# Altium schematic sheet PAGE2-CONNECTIONS.SchDoc(PAGE2-CONNECTIONS)
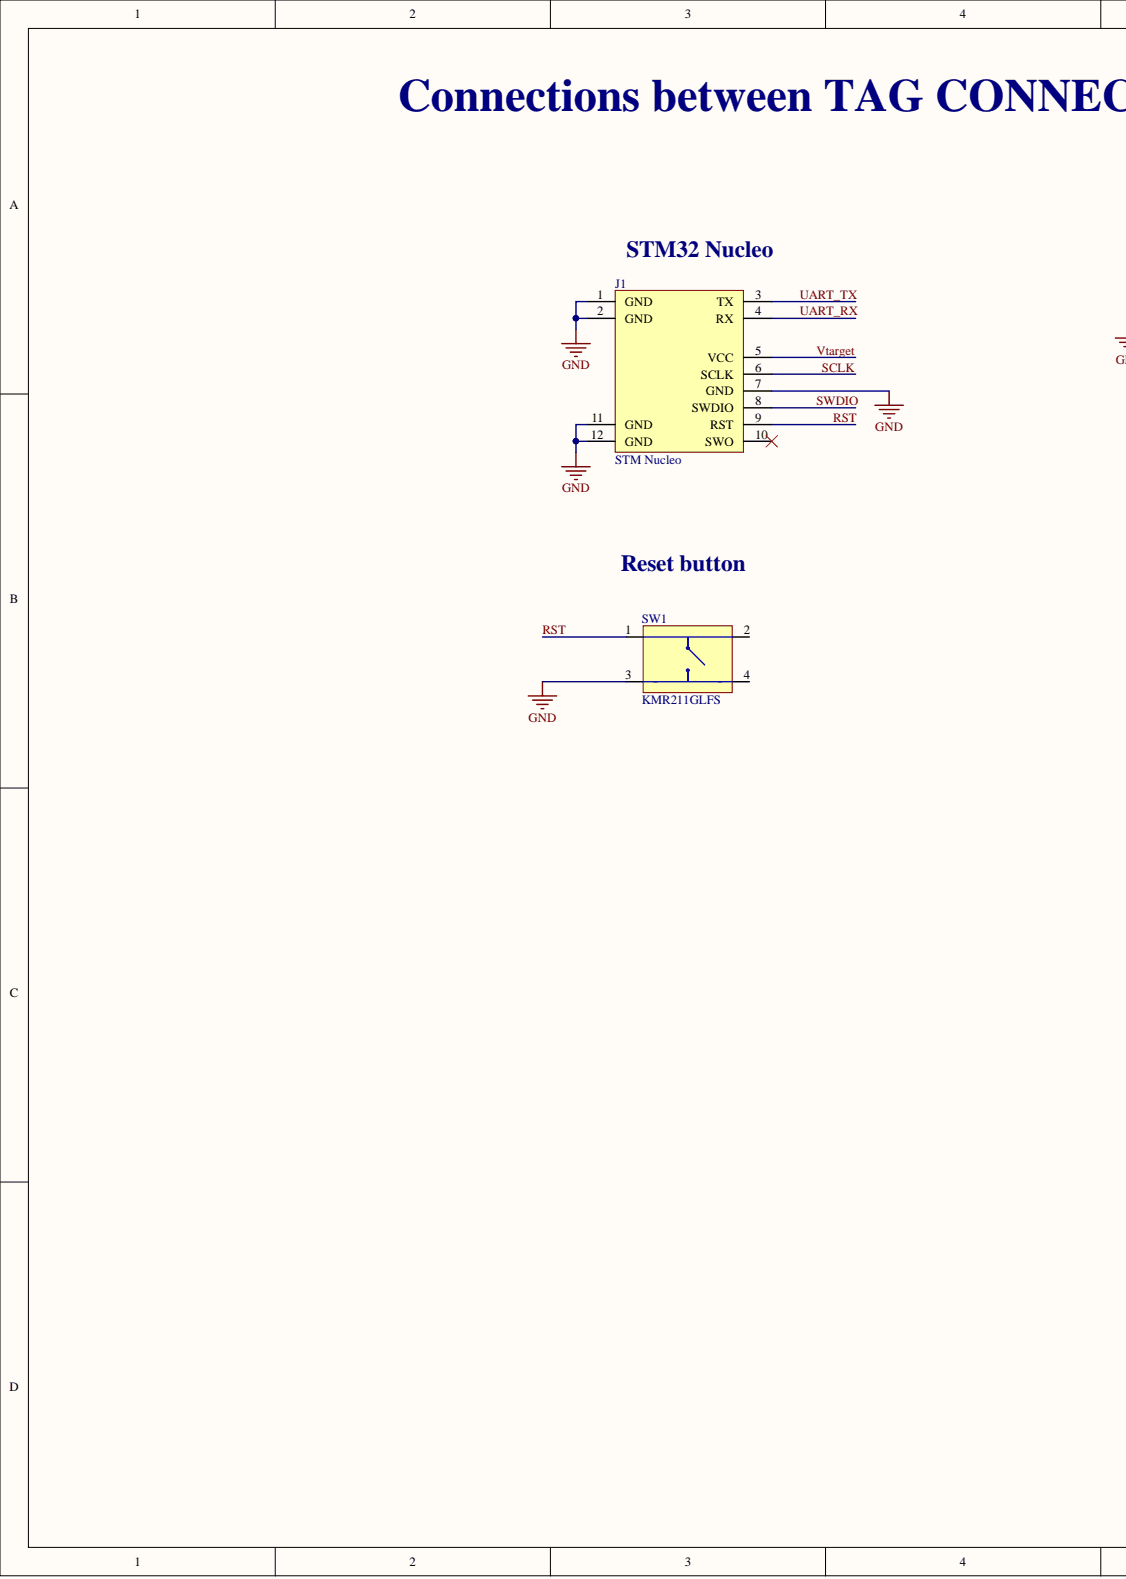
[diagram p0: PAGE2-CONNECTIONS.SchDoc, left]
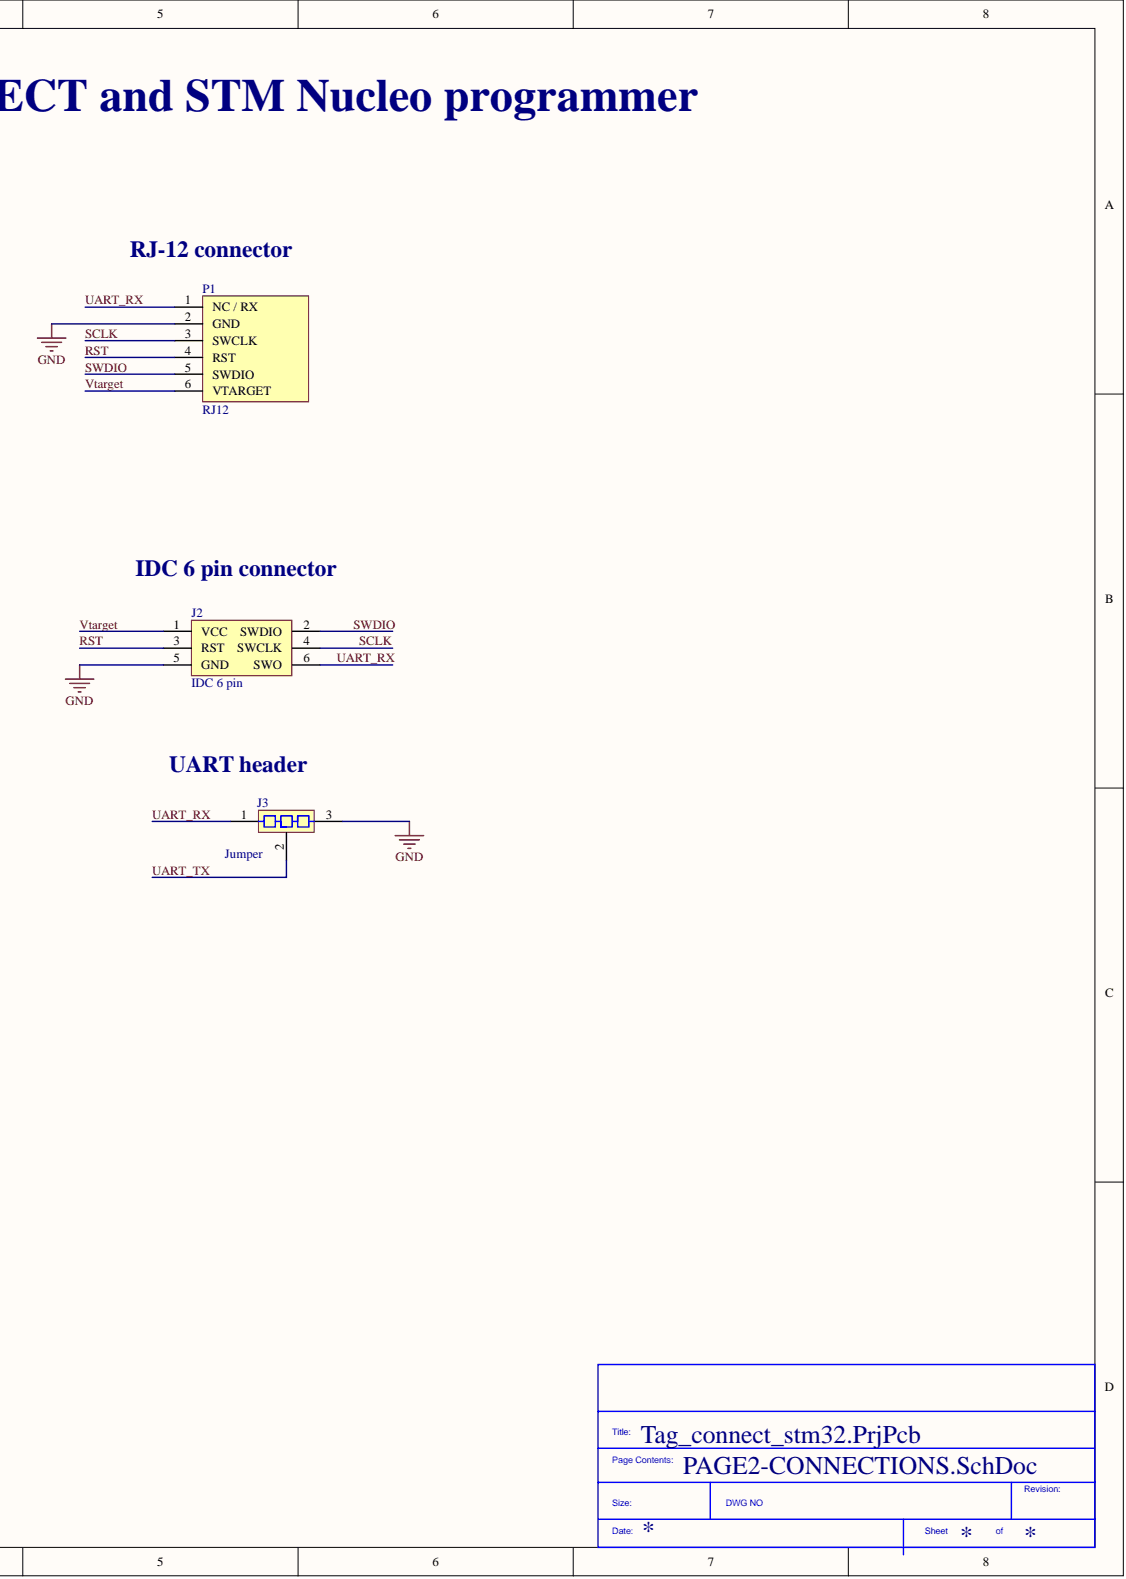
[diagram p1: PAGE2-CONNECTIONS.SchDoc, right]

## Parts
J1  STM Nucleo  [NUCLEO F4 HEADERS]
J2  IDC 6 pin  [IDC 6 pin]
J3  Jumper  [JUMPER]
P1  RJ12  [RJ12]
SW1  KMR211GLFS  [Button]

## Nets
GND: J1.1(GND) J1.2(GND) J1.7(GND) J1.11(GND) J1.12(GND) J2.5(GND) J3.3 P1.2(GND) SW1.3
RST: J1.9(RST) J2.3(RST) P1.4(RST) SW1.1
SCLK: J1.6(SCLK) J2.4(SWCLK) P1.3(SWCLK)
SWDIO: J1.8(SWDIO) J2.2(SWDIO) P1.5(SWDIO)
UART_RX: J1.4(RX) J2.6(SWO) J3.1 P1.1(NC_/_RX)
UART_TX: J1.3(TX) J3.2
Vtarget: J1.5(VCC) J2.1(VCC) P1.6(VTARGET)

Not connected: J1 10(SWO); SW1 2 4

## Library data of the parts
J2 = 90130-1206: Headers & Wire Housings 2.54MM CGRIDIII HDR 6P V DR SHRD AU-E; Manufacturer: Molex; Working Voltage: 350V; Number of Positions: 6; Max Voltage Rating (DC): 350.35kV; Max Voltage Rating (AC): 500V; Max Current Rating: 3.9A; Insulation Resistance: 5GR; Glow Wire Compliant: Non-compliant; Gender: Male; Current Rating: 3A; Contact Resistance: 20mR; Voltage Rating (DC): 350V; Voltage Rating (AC): 350V; Voltage Rating: 350V; Termination: Solder; Supplier Part Number 1: 9733884; Supplier 1: Farnell; Stock 1: 1896; Row Spacing: 2.54mm; REACH SVHC: Unknown; Radiation Hardening: No; Pricing 1: 1=1.07, 50=1, 100=0.934, 250=0.84, 500=0.775, 1000=0.619 (EUR); Pitch: 2.54mm; Packaging: Tray; Package Quantity: 2560; Orientation: Vertical; Number of Rows: 2; Number of Poles: 6; Number of Contacts: 6; Number of Circuits: 6; Mount: Through Hole; Min Operating Temperature: -55°C; Max Operating Temperature: 125°C; Material: Glass,Polyester; Manufacturer Part Number: 90130-1206; Length: 8mm; Lead Pitch: 2.54mm; Lead Free: Lead Free; Housing Material: Polyester; Housing Color: Black; Height: 4.7mm; Depth: 3.94mm; Contact Plating: Gold; Contact Material: Brass,Bronze,Copper; Contact Gender: Male; ComponentLink5URL: http://uk.rs-online.com/webdocs/13c4/0900766b813c4c7b.pdf?src-supplier=RSComponents; ComponentLink5Description: http://uk.rs-online.com/webdocs/13c4/0900766b813c4c7b.pdf?src-supplier=RSComponents; ComponentLink4URL: http://www.molex.com/webdocs/datasheets/pdf/en-us/0901301206_PCB_HEADERS.pdf?src-supplier=Avnet; ComponentLink4Description: http://www.molex.com/webdocs/datasheets/pdf/en-us/0901301206_PCB_HEADERS.pdf?src-supplier=Avnet; ComponentLink3URL: http://www.molex.com/webdocs/datasheets/pdf/en-us/0901301206_PCB_HEADERS.pdf?src-supplier=Avnet+Europe; ComponentLink3Description: http://www.molex.com/webdocs/datasheets/pdf/en-us/0901301206_PCB_HEADERS.pdf?src-supplier=Avnet+Europe; ComponentLink2URL: http://www.jameco.com/Jameco/Products/ProdDS/1978810.pdf?src-supplier=Jameco; ComponentLink2Description: http://www.jameco.com/Jameco/Products/ProdDS/1978810.pdf?src-supplier=Jameco; ComponentLink1URL: http://www.mouser.com/ds/2/276/0901301206_PCB_HEADERS-151694.pdf?src-supplier=Mouser; ComponentLink1Description: http://www.mouser.com/ds/2/276/0901301206_PCB_HEADERS-151694.pdf?src-supplier=Mouser; Component Kind: Standard; Color: Black; Library Name: TC_otii_stlink.SchLib
J3 = Jumper: 3 pin jumper; Component Kind: Standard; Library Name: TC_otii_stlink.SchLib
P1 = 95501-2661: MODULAR, JACK, THT, R/A, RJ11, 6P6C; Manufacturer: Molex; Voltage Rating (DC): 125V; Shielding: Unshielded; Pitch: 2.54mm; Number of Positions: 6; Number of Ports: 1; Insulation Resistance: 500MR; Gender: Female; Current Rating: 1.5A; Approvals: UL; Width: 11.5mm; Termination: Through Hole; Supplier Part Number 1: 1536490; Supplier 1: Farnell; Stock 1: 7441; REACH SVHC: Unknown; Pricing 1: 10=0.565, 100=0.492, 500=0.443, 1000=0.394, 2500=0.356, 5000=0.344 (EUR); Packaging: Tray; Package Quantity: 3276; Orientation: Right Angle; Number of Contacts: 6; Mount: Through Hole; Min Operating Temperature: -40°C; Max Voltage Rating (DC): 125V; Max Operating Temperature: 80°C; Manufacturer Part Number: 95501-2661; Length: 13.21mm; Lead Free: Lead Free; Insulation Material: Polyester; Housing Material: Thermoplastic; Halogen Free: Low Halogen; Flammability Rating: UL94 V-0; Depth: 18.1mm; Contact Plating: Gold; Contact Material: Copper Alloy,Bronze; ComponentLink4URL: http://www.onlinecomponents.com/productFiles/mf-MOL/360890955012661_modular_jacks_plug.pdf?src-supplier=Onlinecomponents.com; ComponentLink4Description: http://www.onlinecomponents.com/productFiles/mf-MOL/360890955012661_modular_jacks_plug.pdf?src-supplier=Onlinecomponents.com; ComponentLink3URL: http://www.mouser.com/ds/2/276/0955012661_MODULAR_JACKS_PLUG-177638.pdf?src-supplier=Mouser; ComponentLink3Description: http://www.mouser.com/ds/2/276/0955012661_MODULAR_JACKS_PLUG-177638.pdf?src-supplier=Mouser; ComponentLink2URL: http://www.farnell.com/cad/1597654.pdf?src-supplier=Element14; ComponentLink2Description: http://www.farnell.com/cad/1597654.pdf?src-supplier=Element14; ComponentLink1URL: http://uk.rs-online.com/webdocs/13c4/0900766b813c4eac.pdf?src-supplier=RSComponents; ComponentLink1Description: http://uk.rs-online.com/webdocs/13c4/0900766b813c4eac.pdf?src-supplier=RSComponents; Component Kind: Standard; Color: Black; Library Name: TC_otii_stlink.SchLib
SW1 = KMR211GLFS: SWITCH TACTILE SPST-NO 0.05A 32V; Manufacturer: ITT C&K; Throw Configuration: SPST; Switch Travel: 0.2mm; Switch Function: Off-Mom; Power Rating: 1W; Operating Force: 1.2N; Mechanical Life: 200000Cycles; Max Current Rating: 50mA; Illumination Type: Non-Illuminated,Illuminated; Actuator Type: Standard; Actuator Length: 0.5mm; Width: 2.8mm; Voltage Rating (DC): 32V; Termination: Gull Wing; Supplier Part Number 1: 1437635RL; Supplier 1: Farnell; Stock 1: 0; REACH SVHC: No SVHC; Radiation Hardening: No; Packaging: Tape and Reel; Package Quantity: 1; Orientation: Straight; Mount: Surface Mount; Min Operating Temperature: -40°C; Max Voltage Rating (DC): 32V; Max Operating Temperature: 85°C; Manufacturer Part Number: KMR211GLFS; Length: 2.8mm; Lead Free: Lead Free; Depth: 4.6mm; Current Rating: 50mA; Contact Plating: Silver; Contact Material: Silver; Contact Current Rating: 50mA; ComponentLink7URL: http://www.ckswitches.com/media/1479/kmr2.pdf?src-supplier=Onlinecomponents.com; ComponentLink7Description: http://www.ckswitches.com/media/1479/kmr2.pdf?src-supplier=Onlinecomponents.com; ComponentLink6URL: https://www.ckswitches.com/media/1479/kmr2.pdf?src-supplier=Digi-Key; ComponentLink6Description: https://www.ckswitches.com/media/1479/kmr2.pdf?src-supplier=Digi-Key; ComponentLink5URL: http://www.ck-components.com/14414/kmr2_9aug12.pdf/?src-supplier=Avnet+Europe; ComponentLink5Description: http://www.ck-components.com/14414/kmr2_9aug12.pdf/?src-supplier=Avnet+Europe; ComponentLink4URL: http://www.ck-components.com/14414/kmr2_9aug12.pdf/?src-supplier=Avnet; ComponentLink4Description: http://www.ck-components.com/14414/kmr2_9aug12.pdf/?src-supplier=Avnet; ComponentLink3URL: http://www.farnell.com/datasheets/2044619.pdf?src-supplier=Element14; ComponentLink3Description: http://www.farnell.com/datasheets/2044619.pdf?src-supplier=Element14; ComponentLink2URL: http://www1.futureelectronics.com/doc/C%20-%20K%20COMPONENTS/KMR211GLFS.pdf?src-supplier=Future+Electronics; ComponentLink2Description: http://www1.futureelectronics.com/doc/C%20-%20K%20COMPONENTS/KMR211GLFS.pdf?src-supplier=Future+Electronics; ComponentLink1URL: http://uk.rs-online.com/webdocs/129c/0900766b8129c9f0.pdf?src-supplier=RSComponents; ComponentLink1Description: http://uk.rs-online.com/webdocs/129c/0900766b8129c9f0.pdf?src-supplier=RSComponents; Component Kind: Standard; Library Name: TC_otii_stlink.SchLib
J1 = STM Nucleo: Component Kind: Standard; Library Name: TC_otii_stlink.SchLib
